# Altium schematic sheet ZYNQ_SD.SchDoc(ZYNQ_SD)
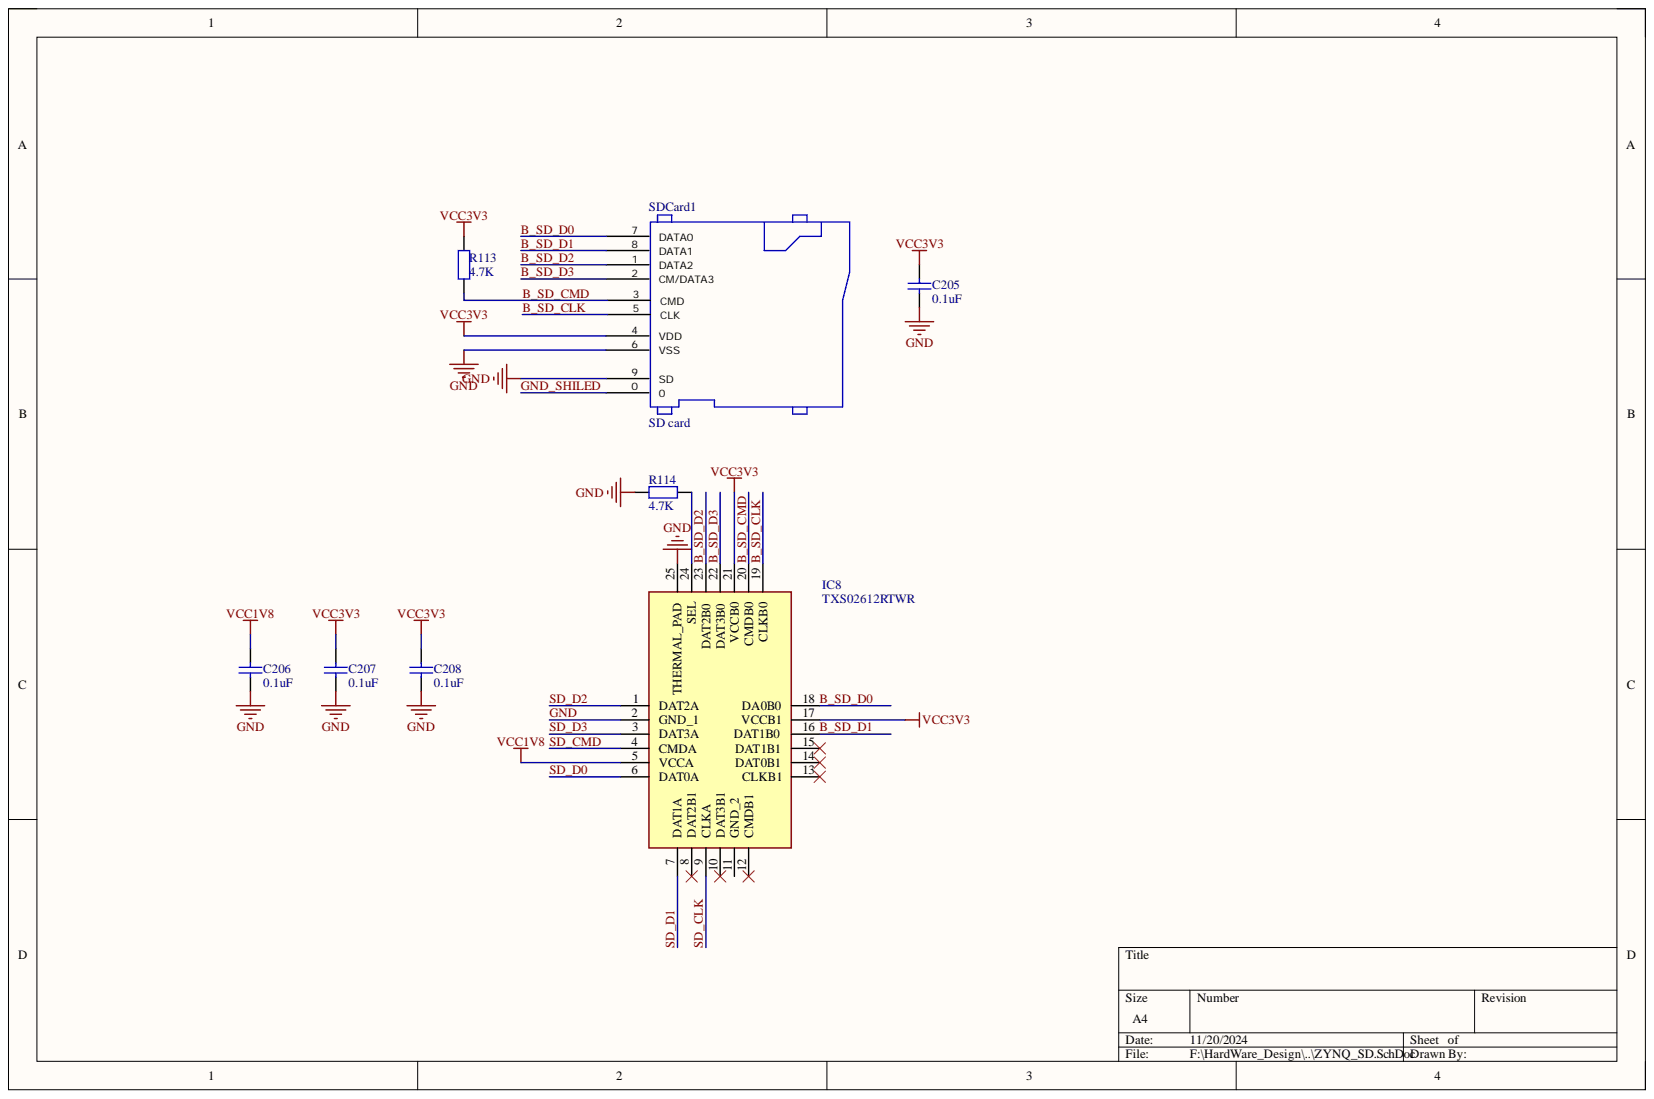
[diagram p0: ZYNQ_SD.SchDoc]

## Parts
C205  0.1uF  [C 0402_L]
C206  0.1uF  [C 0402_L]
C207  0.1uF  [C 0402_L]
C208  0.1uF  [C 0402_L]
IC8  TXS02612RTWR  [QFN50P400X400X80-25N-D]
R113  4.7K  [R 0402_L]
R114  4.7K  [R 0402_L]
SDCard1  SD card  [SD_CARD]

## Nets
VCC1V8: C206.2 IC8.5(VCCA)
VCC3V3: C205.2 C207.2 C208.2 IC8.17(VCCB1) IC8.21(VCCB0) R113.2 SDCard1.4(VDD)
B_SD_CLK: IC8.19(CLKB0) SDCard1.5(CLK)
B_SD_CMD: IC8.20(CMDB0) R113.1 SDCard1.3(CMD)
B_SD_D0: IC8.18(DA0B0) SDCard1.7(DATA0)
B_SD_D1: IC8.16(DAT1B0) SDCard1.8(DATA1)
B_SD_D2: IC8.23(DAT2B0) SDCard1.1(DATA2)
B_SD_D3: IC8.22(DAT3B0) SDCard1.2(CM/DATA3)
GND: C205.1 C206.1 C207.1 C208.1 IC8.2(GND_1) IC8.25(THERMAL_PAD) R114.2 SDCard1.6(VSS) SDCard1.9
GND_SHILED: SDCard1.0
SD_CLK: IC8.9(CLKA)
SD_CMD: IC8.4(CMDA)
SD_D0: IC8.6(DAT0A)
SD_D1: IC8.7(DAT1A)
SD_D2: IC8.1(DAT2A)
SD_D3: IC8.3(DAT3A)
NetIC8_24: IC8.24(SEL) R114.1

Not connected: IC8 8(DAT2B1) 10(DAT3B1) 11(GND_2) 12(CMDB1) 13(CLKB1) 14(DAT0B1) 15(DAT1B1)

## Library data of the parts
C205-C208 = Cap: 贴片电容; Component Kind: Standard; Library Name: A_Schlib.SchLib; value: 0.1uF
IC8 = TXS02612RTWR: Integrated Circuit; Manufacturer_Name: Texas Instruments; Arrow Part Number: TXS02612RTWR; Arrow Price/Stock: https://www.arrow.com/en/products/txs02612rtwr/texas-instruments?region=nac; Component Kind: Standard; Datasheet Link: http://www.ti.com/lit/gpn/txs02612; Height: 0.8mm; Library Name: SamacSys.SchLib; Manufacturer_Part_Number: TXS02612RTWR; Mouser Part Number: 595-TXS02612RTWR; Mouser Price/Stock: https://www.mouser.co.uk/ProductDetail/Texas-Instruments/TXS02612RTWR?qs=dqESbKqT1jaNFKC1R4M7hw%3D%3D
R113 R114 = Res: 通用; Component Kind: Standard; Library Name: A_Schlib.SchLib; value: 4.7K
SDCard1 = SD card: 通用; Component Kind: Standard; Library Name: A_Schlib.SchLib
